# Altium schematic sheet 05fpga-pwr.SchDoc(05fpga-pwr)
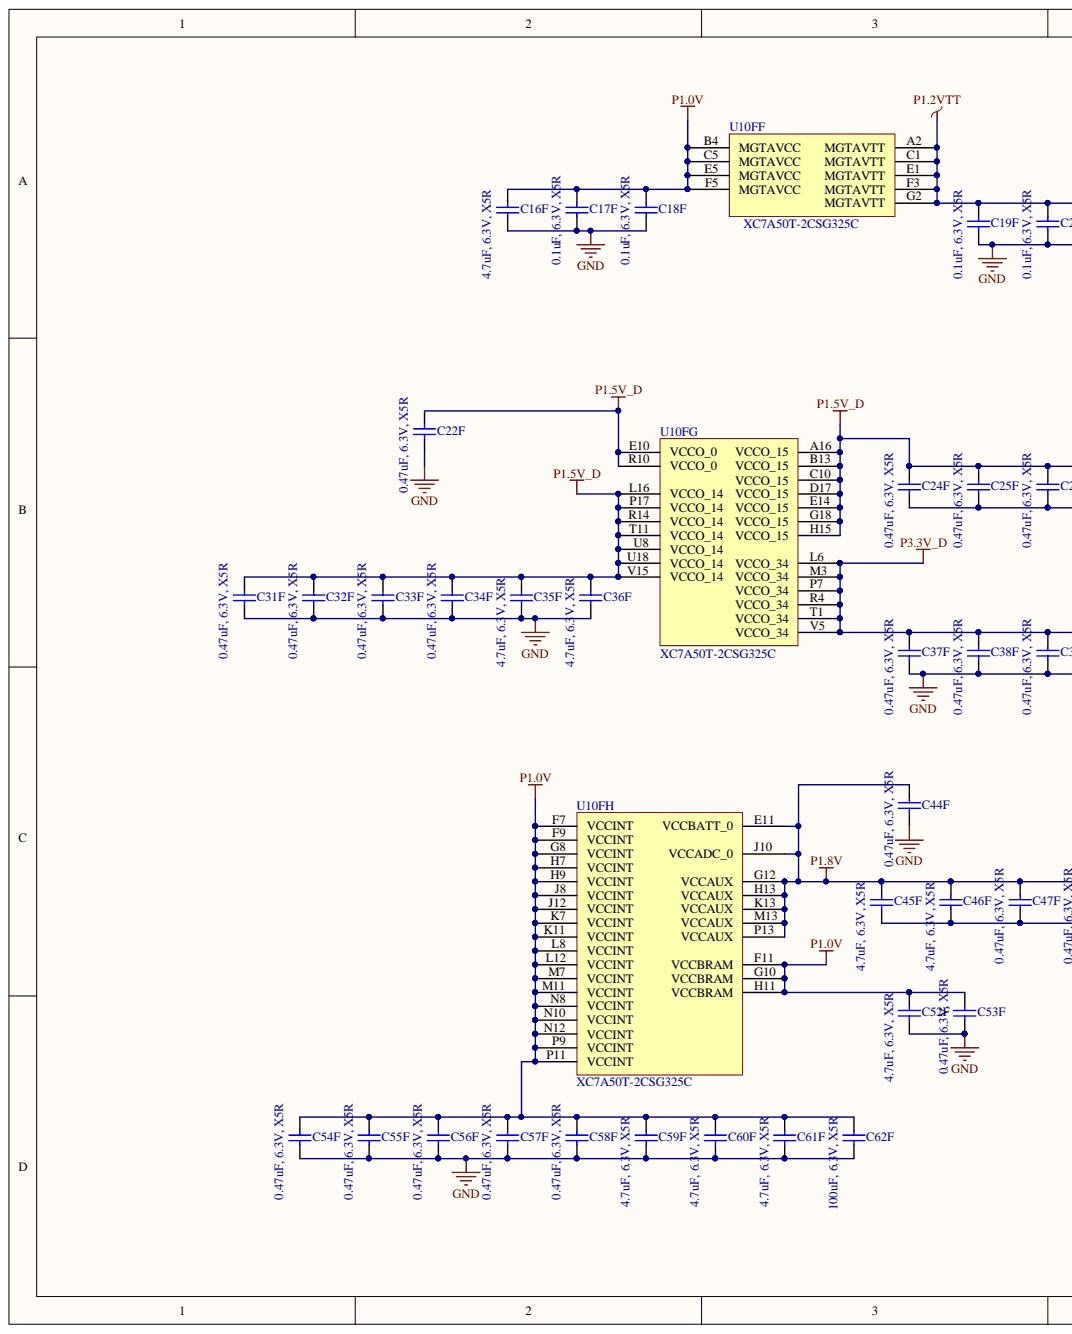
[diagram p0: 05fpga-pwr.SchDoc, left]
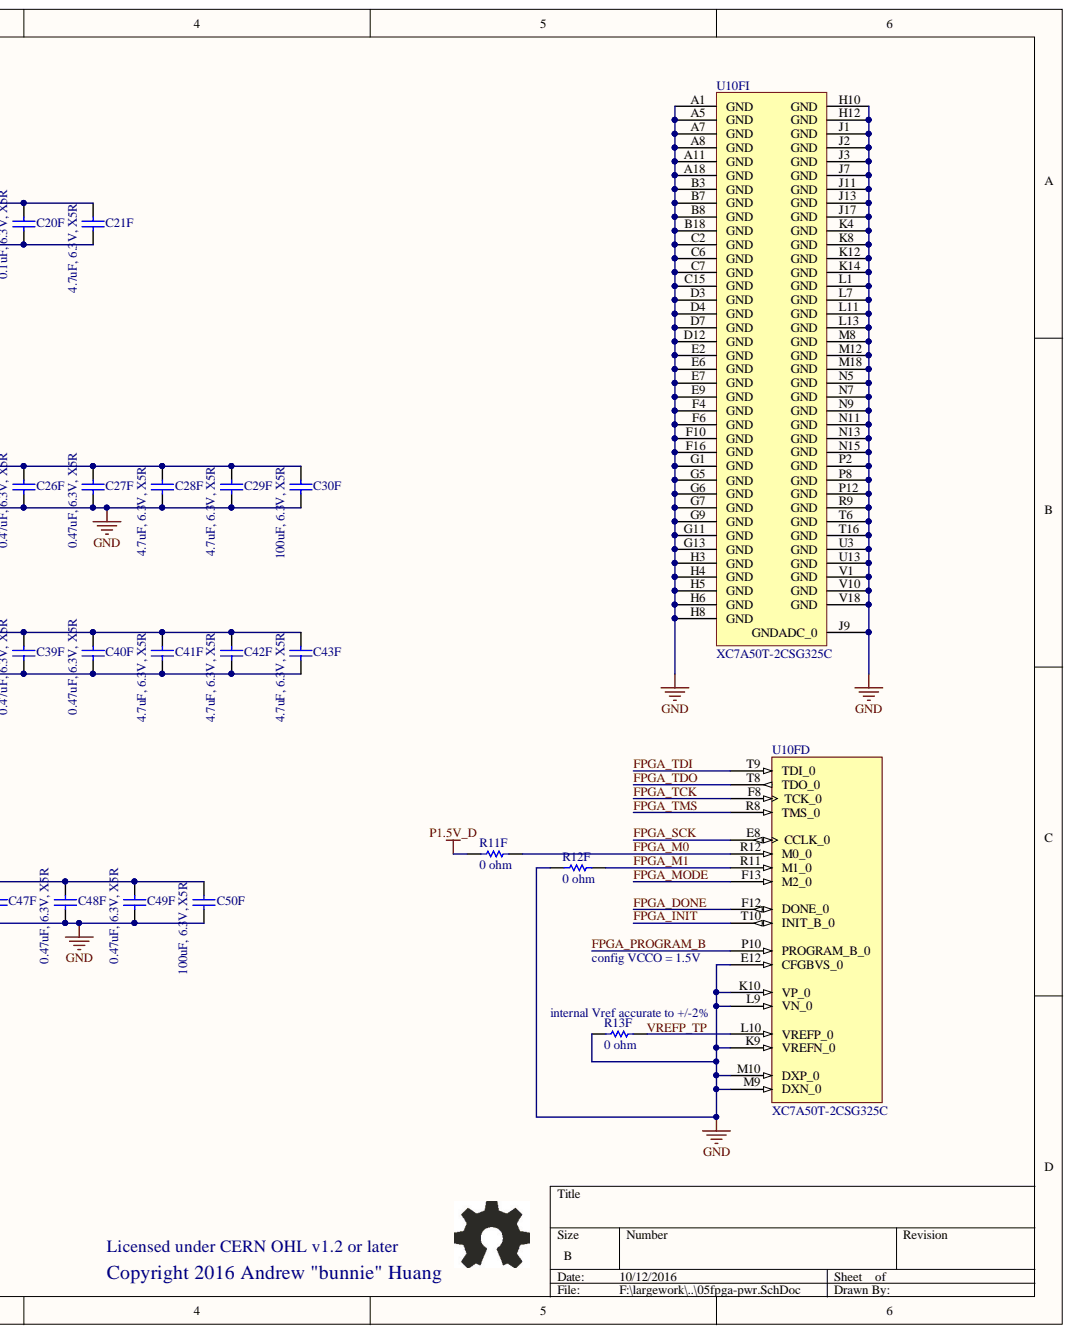
[diagram p1: 05fpga-pwr.SchDoc, right]

## Parts
C16F  4.7uF, 6.3V, X5R  [CAPC1005N]
C17F  0.1uF, 6.3V, X5R  [CAPC0603X03L]
C18F  0.1uF, 6.3V, X5R  [CAPC0603X03L]
C19F  0.1uF, 6.3V, X5R  [CAPC0603X03L]
C20F  0.1uF, 6.3V, X5R  [CAPC0603X03L]
C21F  4.7uF, 6.3V, X5R  [CAPC1005N]
C22F  0.47uF, 6.3V, X5R  [CAPC0603X03L]
C24F  0.47uF, 6.3V, X5R  [CAPC0603X03L]
C25F  0.47uF, 6.3V, X5R  [CAPC0603X03L]
C26F  0.47uF, 6.3V, X5R  [CAPC0603X03L]
C27F  0.47uF, 6.3V, X5R  [CAPC0603X03L]
C28F  4.7uF, 6.3V, X5R  [CAPC1005N]
C29F  4.7uF, 6.3V, X5R  [CAPC1005N]
C30F  100uF, 6.3V, X5R  [CAPC3216N]
C31F  0.47uF, 6.3V, X5R  [CAPC0603X03L]
C32F  0.47uF, 6.3V, X5R  [CAPC0603X03L]
C33F  0.47uF, 6.3V, X5R  [CAPC0603X03L]
C34F  0.47uF, 6.3V, X5R  [CAPC0603X03L]
C35F  4.7uF, 6.3V, X5R  [CAPC1005N]
C36F  4.7uF, 6.3V, X5R  [CAPC1005N]
C37F  0.47uF, 6.3V, X5R  [CAPC0603X03L]
C38F  0.47uF, 6.3V, X5R  [CAPC0603X03L]
C39F  0.47uF, 6.3V, X5R  [CAPC0603X03L]
C40F  0.47uF, 6.3V, X5R  [CAPC0603X03L]
C41F  4.7uF, 6.3V, X5R  [CAPC1005N]
C42F  4.7uF, 6.3V, X5R  [CAPC1005N]
C43F  4.7uF, 6.3V, X5R  [CAPC1005N]
C44F  0.47uF, 6.3V, X5R  [CAPC0603X03L]
C45F  4.7uF, 6.3V, X5R  [CAPC1005N]
C46F  4.7uF, 6.3V, X5R  [CAPC1005N]
C47F  0.47uF, 6.3V, X5R  [CAPC0603X03L]
C48F  0.47uF, 6.3V, X5R  [CAPC0603X03L]
C49F  0.47uF, 6.3V, X5R  [CAPC0603X03L]
C50F  100uF, 6.3V, X5R  [CAPC3216N]
C52F  4.7uF, 6.3V, X5R  [CAPC1005N]
C53F  0.47uF, 6.3V, X5R  [CAPC0603X03L]
C54F  0.47uF, 6.3V, X5R  [CAPC0603X03L]
C55F  0.47uF, 6.3V, X5R  [CAPC0603X03L]
C56F  0.47uF, 6.3V, X5R  [CAPC0603X03L]
C57F  0.47uF, 6.3V, X5R  [CAPC0603X03L]
C58F  0.47uF, 6.3V, X5R  [CAPC0603X03L]
C59F  4.7uF, 6.3V, X5R  [CAPC1005N]
C60F  4.7uF, 6.3V, X5R  [CAPC1005N]
C61F  4.7uF, 6.3V, X5R  [CAPC1005N]
C62F  100uF, 6.3V, X5R  [CAPC3216N]
R11F  0 ohm  [RESC1005N]
R12F  0 ohm  [RESC1005N]
R13F  0 ohm  [RESC1005N]
U10F  XC7A50T-2CSG325C  [CSG325]  (parts D,F,G,H,I)

## Nets
GND: C16F.2 C17F.2 C18F.2 C19F.2 C20F.2 C21F.2 C22F.2 C24F.2 C25F.2 C26F.2 C27F.2 C28F.2 C29F.2 C30F.2 C31F.2 C32F.2 C33F.2 C34F.2 C35F.2 C36F.2 C37F.2 C38F.2 C39F.2 C40F.2 C41F.2 C42F.2 C43F.2 C44F.2 C45F.2 C46F.2 C47F.2 C48F.2 C49F.2 C50F.2 C52F.2 C53F.2 C54F.2 C55F.2 C56F.2 C57F.2 C58F.2 C59F.2 C60F.2 C61F.2 C62F.2 R12F.2 R13F.2 U10F.A1(GND) U10F.A5(GND) U10F.A7(GND) U10F.A8(GND) U10F.A11(GND) U10F.A18(GND) U10F.B3(GND) U10F.B7(GND) U10F.B8(GND) U10F.B18(GND) U10F.C2(GND) U10F.C6(GND) U10F.C7(GND) U10F.C15(GND) U10F.D3(GND) U10F.D4(GND) U10F.D7(GND) U10F.D12(GND) U10F.E2(GND) U10F.E6(GND) U10F.E7(GND) U10F.E9(GND) U10F.E12(CFGBVS_0) U10F.F4(GND) U10F.F6(GND) U10F.F10(GND) U10F.F16(GND) U10F.G1(GND) U10F.G5(GND) U10F.G6(GND) U10F.G7(GND) U10F.G9(GND) U10F.G11(GND) U10F.G13(GND) U10F.H3(GND) U10F.H4(GND) U10F.H5(GND) U10F.H6(GND) U10F.H8(GND) U10F.H10(GND) U10F.H12(GND) U10F.J1(GND) U10F.J2(GND) U10F.J3(GND) U10F.J7(GND) U10F.J9(GNDADC_0) U10F.J11(GND) U10F.J13(GND) U10F.J17(GND) U10F.K4(GND) U10F.K8(GND) U10F.K9(VREFN_0) U10F.K10(VP_0) U10F.K12(GND) U10F.K14(GND) U10F.L1(GND) U10F.L7(GND) U10F.L9(VN_0) U10F.L11(GND) U10F.L13(GND) U10F.M8(GND) U10F.M9(DXN_0) U10F.M10(DXP_0) U10F.M12(GND) U10F.M18(GND) U10F.N5(GND) U10F.N7(GND) U10F.N9(GND) U10F.N11(GND) U10F.N13(GND) U10F.N15(GND) U10F.P2(GND) U10F.P8(GND) U10F.P12(GND) U10F.R9(GND) U10F.T6(GND) U10F.T16(GND) U10F.U3(GND) U10F.U13(GND) U10F.V1(GND) U10F.V10(GND) U10F.V18(GND)
P1.0V: C16F.1 C17F.1 C18F.1 C52F.1 C53F.1 C54F.1 C55F.1 C56F.1 C57F.1 C58F.1 C59F.1 C60F.1 C61F.1 C62F.1 U10F.B4(MGTAVCC) U10F.C5(MGTAVCC) U10F.E5(MGTAVCC) U10F.F5(MGTAVCC) U10F.F7(VCCINT) U10F.F9(VCCINT) U10F.F11(VCCBRAM) U10F.G8(VCCINT) U10F.G10(VCCBRAM) U10F.H7(VCCINT) U10F.H9(VCCINT) U10F.H11(VCCBRAM) U10F.J8(VCCINT) U10F.J12(VCCINT) U10F.K7(VCCINT) U10F.K11(VCCINT) U10F.L8(VCCINT) U10F.L12(VCCINT) U10F.M7(VCCINT) U10F.M11(VCCINT) U10F.N8(VCCINT) U10F.N10(VCCINT) U10F.N12(VCCINT) U10F.P9(VCCINT) U10F.P11(VCCINT)
P1.2VTT: C19F.1 C20F.1 C21F.1 U10F.A2(MGTAVTT) U10F.C1(MGTAVTT) U10F.E1(MGTAVTT) U10F.F3(MGTAVTT) U10F.G2(MGTAVTT)
P1.5V_D: C22F.1 C24F.1 C25F.1 C26F.1 C27F.1 C28F.1 C29F.1 C30F.1 C31F.1 C32F.1 C33F.1 C34F.1 C35F.1 C36F.1 R11F.2 U10F.A16(VCCO_15) U10F.B13(VCCO_15) U10F.C10(VCCO_15) U10F.D17(VCCO_15) U10F.E10(VCCO_0) U10F.E14(VCCO_15) U10F.G18(VCCO_15) U10F.H15(VCCO_15) U10F.L16(VCCO_14) U10F.P17(VCCO_14) U10F.R10(VCCO_0) U10F.R14(VCCO_14) U10F.T11(VCCO_14) U10F.U8(VCCO_14) U10F.U18(VCCO_14) U10F.V15(VCCO_14)
P1.8V: C44F.1 C45F.1 C46F.1 C47F.1 C48F.1 C49F.1 C50F.1 U10F.E11(VCCBATT_0) U10F.G12(VCCAUX) U10F.H13(VCCAUX) U10F.J10(VCCADC_0) U10F.K13(VCCAUX) U10F.M13(VCCAUX) U10F.P13(VCCAUX)
P3.3V_D: C37F.1 C38F.1 C39F.1 C40F.1 C41F.1 C42F.1 C43F.1 U10F.L6(VCCO_34) U10F.M3(VCCO_34) U10F.P7(VCCO_34) U10F.R4(VCCO_34) U10F.T1(VCCO_34) U10F.V5(VCCO_34)
FPGA_DONE: U10F.F12(DONE_0)
FPGA_INIT: U10F.T10(INIT_B_0)
FPGA_M0: R11F.1 U10F.R12(M0_0)
FPGA_M1: R12F.1 U10F.R11(M1_0)
FPGA_MODE: U10F.F13(M2_0)
FPGA_PROGRAM_B: U10F.P10(PROGRAM_B_0)
FPGA_SCK: U10F.E8(CCLK_0)
FPGA_TCK: U10F.F8(TCK_0)
FPGA_TDI: U10F.T9(TDI_0)
FPGA_TDO: U10F.T8(TDO_0)
FPGA_TMS: U10F.R8(TMS_0)
VREFP_TP: R13F.1 U10F.L10(VREFP_0)

## Library data of the parts
C16F C21F C28F C29F C35F C36F C41F C42F C43F C45F C46F C52F C59F C60F C61F = Cap Semi: Capacitor (Semiconductor SIM Model); MPN: CL05A475MQ5NQNC; MYPN: C-475-6p3-X5R-0402; VENDOR: Samsung; Component Kind: Standard; Library Name: Miscellaneous Devices.IntLib; Signal Integrity: Cap Semi; Simulation: CAP
C17F C18F C19F C20F = Cap Semi: Capacitor (Semiconductor SIM Model); MPN: CL03A104KQ3NNNC; MYPN: C-104-6p3-X5R-0201; VENDOR: Samsung; Component Kind: Standard; Library Name: Miscellaneous Devices.IntLib; Signal Integrity: Cap Semi; Simulation: CAP
C22F C24F C25F C26F C27F C31F C32F C33F C34F C37F C38F C39F C40F C44F C47F C48F C49F C53F C54F C55F C56F C57F C58F = Cap Semi: Capacitor (Semiconductor SIM Model); MPN: CL03A474KQ3NNNH; MYPN: C-474-6p3-X5R-0201; VENDOR: Samsung; Component Kind: Standard; Library Name: Miscellaneous Devices.IntLib; Signal Integrity: Cap Semi; Simulation: CAP
C30F C50F C62F = Cap Semi: Capacitor (Semiconductor SIM Model); MPN: CL31A107MQHNNNE; MYPN: C-107-6p3-X5R-1206; VENDOR: Samsung; Component Kind: Standard; Library Name: Miscellaneous Devices.IntLib; Signal Integrity: Cap Semi; Simulation: CAP
R11F R12F R13F = Res1: Resistor; MPN: ANY; MYPN: R-0R0-1P-1_16W-0402; Component Kind: Standard; Library Name: Miscellaneous Devices.IntLib; Signal Integrity: Res; Simulation: RESISTOR; VENDOR: ANY
U10F = XC7A35T-2CSG325C: Artix-7 FPGA, 150 User I/Os, 4 GTP, 324-Ball BGA, Speed Grade 2, Commercial Grade, Pb-Free; Available User I/O: 150; Clock Mgmt Tiles (CMTs): 5; GTP: 4; Logic Cells: 33280; MYPN: U-XC7A50T-2CSG325C; Speed Grades: 2; Total Block RAM: 1800 Kb; VENDOR: Xilinx; Component Kind: Standard; ComponentLink1Description: Manufacturer URL; ComponentLink1URL: http://www.xilinx.com/; ComponentLink2Description: Datasheet; ComponentLink2URL: http://www.xilinx.com/support/documentation/data_sheets/ds180_7Series_Overview.pdf; ComponentLink3Description: Package Specification; ComponentLink3URL: http://www.xilinx.com/support/documentation/user_guides/ug475_7Series_Pkg_Pinout.pdf; ComponentLink4Description: DC and Switching Characteristics; ComponentLink4URL: http://www.xilinx.com/support/documentation/data_sheets/ds181_Artix_7_Data_Sheet.pdf; ComponentLink5Description: Package File; ComponentLink5URL: http://www.xilinx.com/support/packagefiles/a7packages/xc7a200tsbg484pkg.txt; ComponentLink6Description: Packaging and Pinout; ComponentLink6URL: http://www.xilinx.com/support/documentation/user_guides/ug475_7Series_Pkg_Pinout.pdf; DatasheetVersion: v1.15; Library Name: Xilinx Artix-7.IntLib; Maximum Distributed RAM: 400 Kb; Mounting Technology: Surface Mount; MPN: XC7A50T-2CSG325C; NexusDeviceID: XC7A35T-2CSG325C; Operating Temperature Range: Commercial; PackageDescription: 324-Ball Wire-Bond Chip-Scale BGA, Body 15 x 15 mm, Pitch 0.8 mm; PackageReference: CSG-325; PackageVersion: Rev. 1.11
